# Altium schematic sheet power.SchDoc(power)
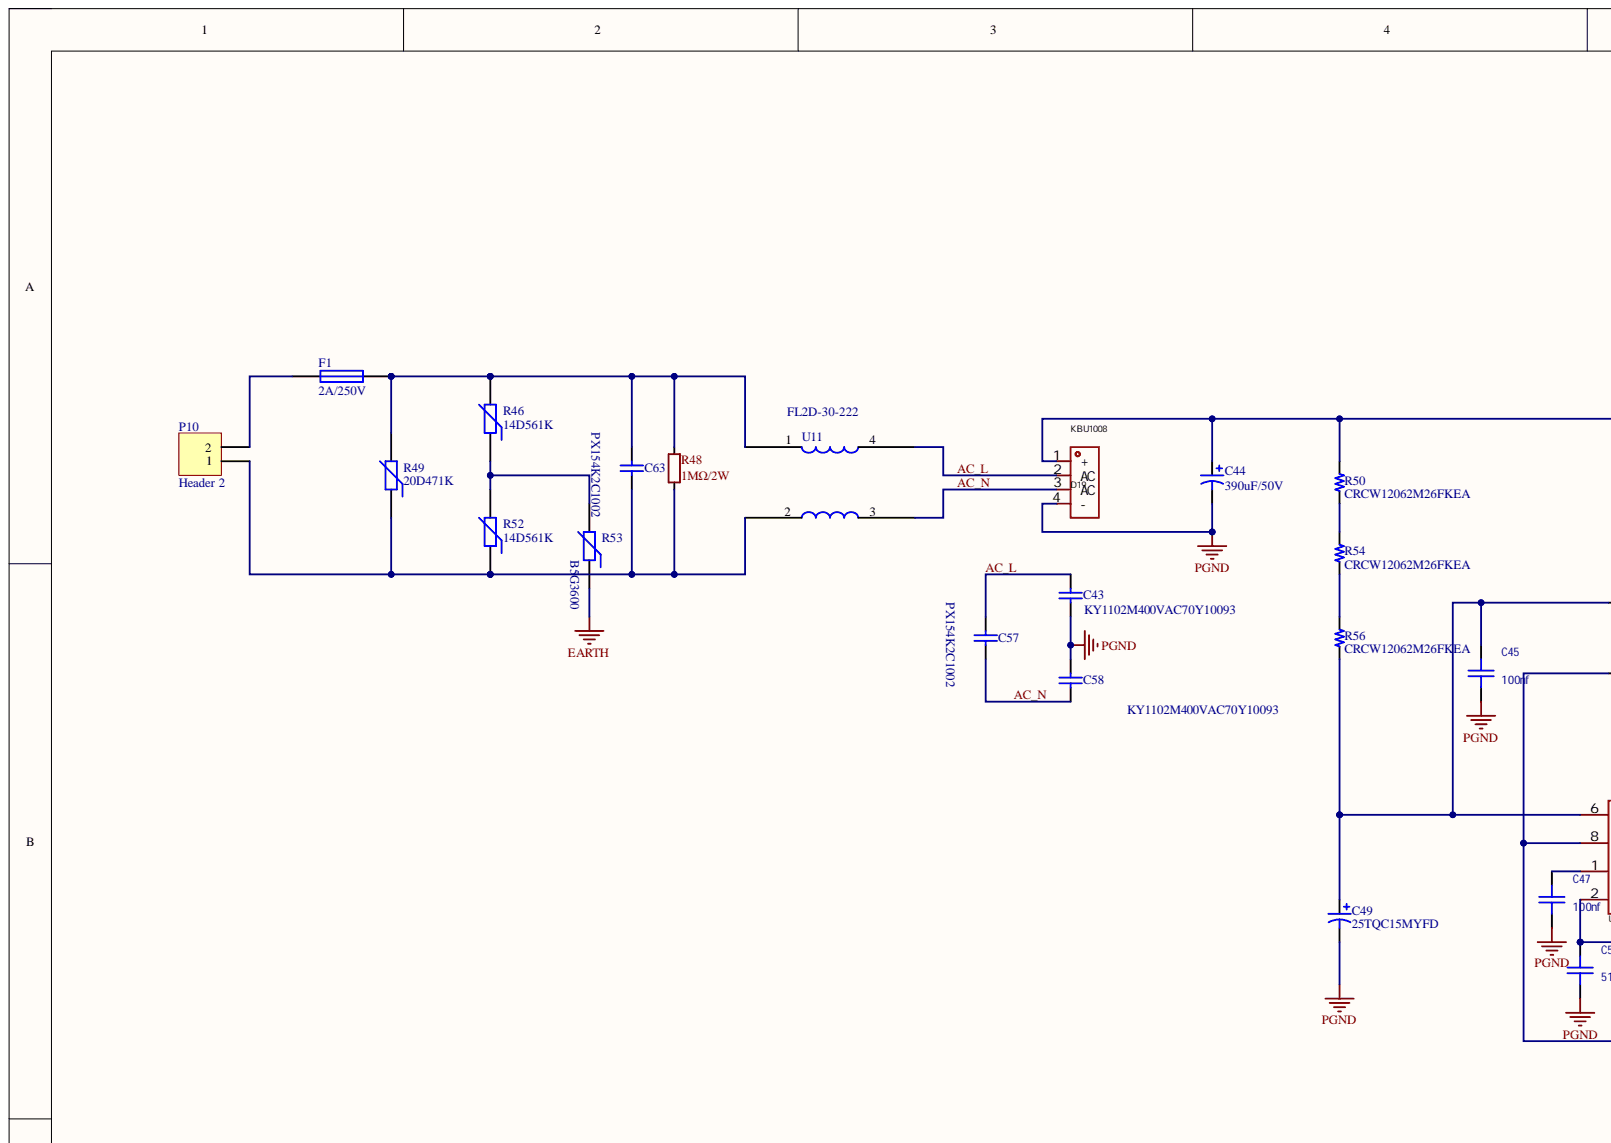
[diagram p0: power.SchDoc, top left]
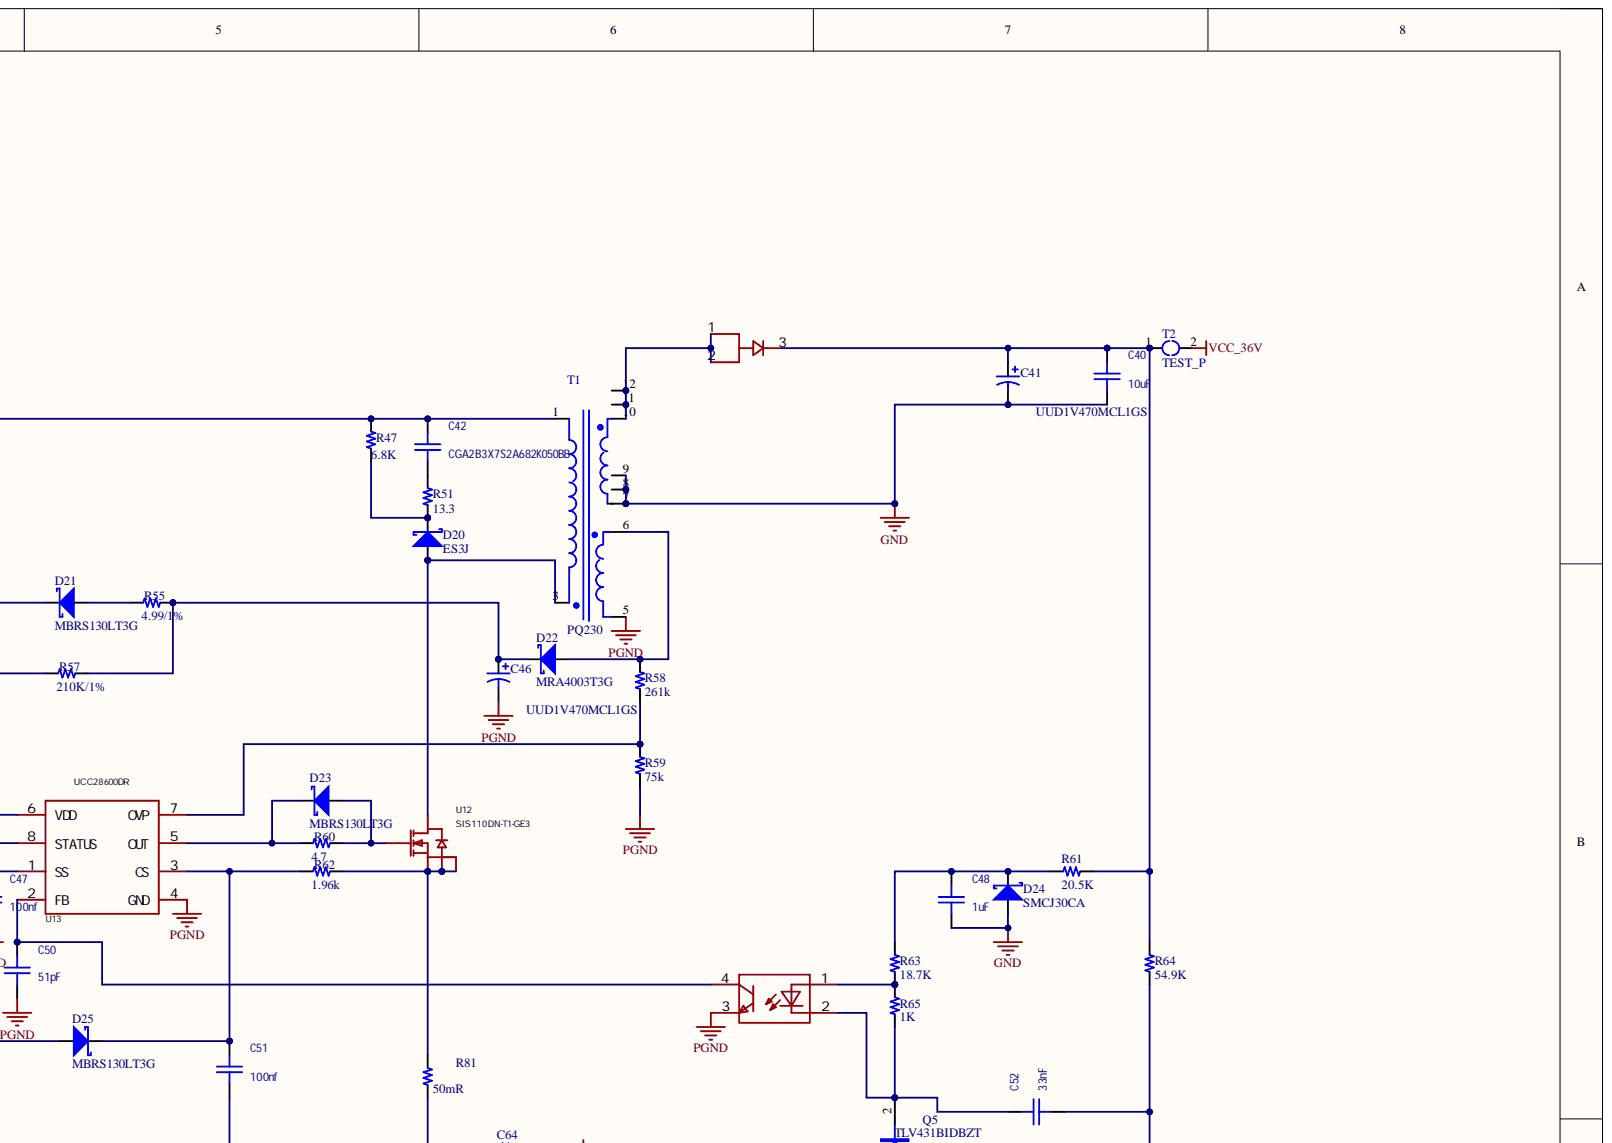
[diagram p1: power.SchDoc, top right]
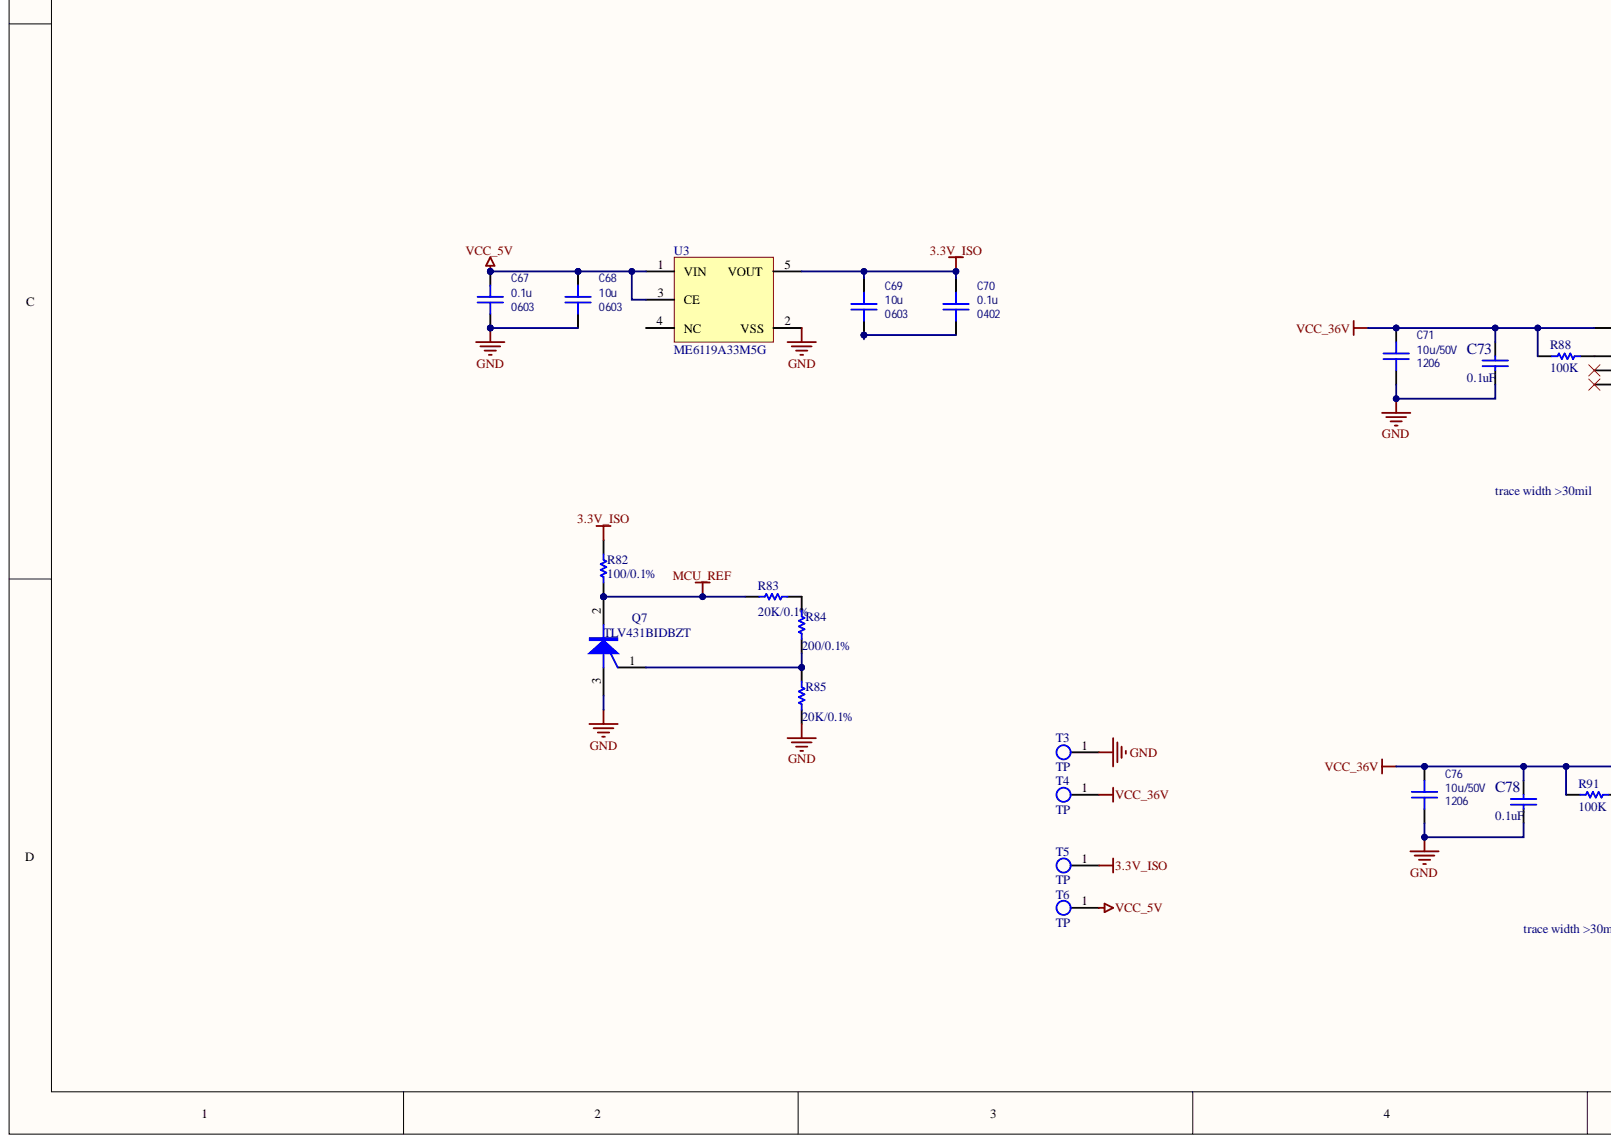
[diagram p2: power.SchDoc, bottom left]
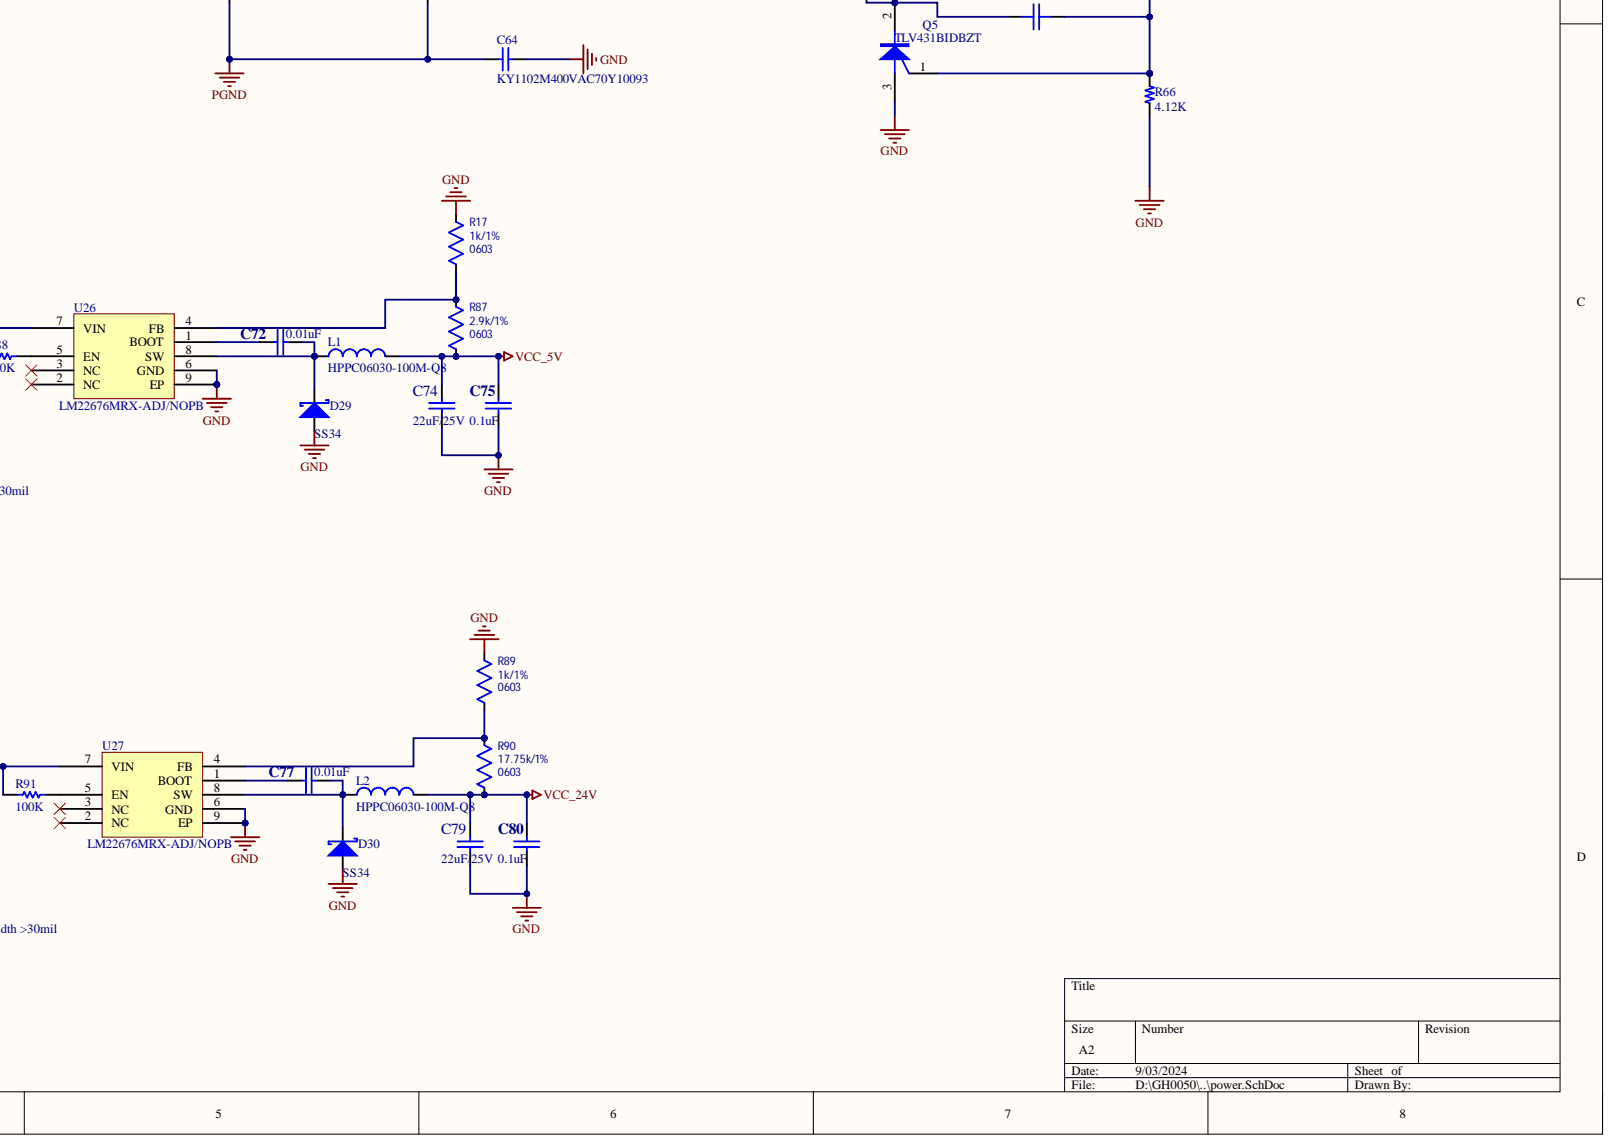
[diagram p3: power.SchDoc, bottom right]

## Parts
C40  Package: 10uF  [0603c]  (hidden comment: Capacitor_0603)
C41  UUD1V470MCL1GS  [Φ10×10]
C42  Package: CGA2B3X7S2A682K050BB  [0402c]  (hidden comment: Capacitor_0603)
C43  KY1102M400VAC70Y10093  [CAP-TH_L7.5-W4.5-P10.00-D0.6]
C44  390uF/50V  [LGX2H391MELC50]
C45  Package: 100nf  [0603c]  (hidden comment: Capacitor_0603)
C46  UUD1V470MCL1GS  [Φ10×10]
C47  Package: 100nf  [0603c]  (hidden comment: Capacitor_0603)
C48  Capacitor_0603  [0402c]  (value not drawn)
C49  25TQC15MYFD  [7343]
C50  Package: 51pF  [0603c]  (hidden comment: Capacitor_0603)
C51  Package: 100nf  [0603c]  (hidden comment: Capacitor_0603)
C52  Package: 33nF  [0603c]  (hidden comment: Capacitor_0603)
C57  PX154K2C1002  [CAP-TH_L13.0-W6.0-P10.00-D0.6]
C58  KY1102M400VAC70Y10093  [CAP-TH_L7.5-W4.5-P10.00-D0.6]
C63  PX154K2C1002  [CAP-TH_L13.0-W6.0-P10.00-D0.6]
C64  KY1102M400VAC70Y10093  [CAP-TH_L7.5-W4.5-P10.00-D0.6]
C67  value 0.1u  Package: 0603  [CAPC1608X092N_0603]  (hidden comment: Capacitor_0603)
C68  value 10u  Package: 0603  [CAPC1608X092N_0603]  (hidden comment: Capacitor_0603)
C69  value 10u  Package: 0603  [CAPC1608X092N_0603]  (hidden comment: Capacitor_0603)
C70  value 0.1u  Package: 0402  [CAPC1005X056N_0402]  (hidden comment: Capacitor_0402)
C71  value 10u/50V  Package: 1206  [1206C]  (hidden comment: Capacitor_1206)
C72  0.01uF  [0603C]
C73  0.1uF  [0603C]
C74  22uF/25V  [1206C]
C75  0.1uF  [0603C]
C76  value 10u/50V  Package: 1206  [1206C]  (hidden comment: Capacitor_1206)
C77  0.01uF  [0603C]
C78  0.1uF  [0603C]
C79  22uF/25V  [1206C]
C80  0.1uF  [0603C]
D18  SVT12100VB_R2_00001  [TO-277B_3P-L6.1-W4.3-LS7.0-BR]  (value not drawn)
D19  KBU1008  [KBU_4P-L23.2-W6.8-P5.10]
D20  ES3J  [SMC]
D21  MBRS130LT3G  [SMB]
D22  MRA4003T3G  [SMA]
D23  MBRS130LT3G  [SMB]
D24  SMCJ30CA  [SMC]
D25  MBRS130LT3G  [SMB]
D29  SS34  [SMA]
D30  SS34  [SMA]
F1  2A/250V  [可视开关电源保险丝座]
L1  HPPC06030-100M-Q8  [IND-SMD-L7.3-W6]
L2  HPPC06030-100M-Q8  [IND-SMD-L7.3-W6]
P10  Header 2  [HDR1X2]
Q5  TLV431BIDBZT  [SOT23-3]
Q7  TLV431BIDBZT  [SOT23-3]
R17  value 1k/1%  Package: 0603  [0603R]  (hidden comment: Resistor_0603)
R46  14D561K  [14D561K]
R47  6.8K  [0603R]
R48  1MΩ/2W  [5x15.5]
R49  20D471K  [20D471K]
R50  CRCW12062M26FKEA  [1206R]
R51  13.3  [0603R]
R52  14D561K  [14D561K]
R53  B5G3600  [B5G3600]
R54  CRCW12062M26FKEA  [1206R]
R55  4.99/1%  [0603R]
R56  CRCW12062M26FKEA  [1206R]
R57  210K/1%  [0603R]
R58  261k  [0603R]
R59  75k  [0603R]
R60  4.7  [0603R]
R61  20.5K  [0603R]
R62  1.96k  [0603R]
R63  18.7K  [0603R]
R64  54.9K  [0603R]
R65  1K  [0603R]
R66  4.12K  [0603R]
R81  50mR  [2512R]
R82  100/0.1%  [0603R]
R83  value 20K/0.1%  [0603R]  (hidden comment: 1K/0.1%)
R84  value 200/0.1%  [0603R]  (hidden comment: 1K/0.1%)
R85  value 20K/0.1%  [0603R]  (hidden comment: 1K/0.1%)
R87  value 2.9k/1%  Package: 0603  [0603R]  (hidden comment: Resistor_0603)
R88  100K  [0603R]
R89  value 1k/1%  Package: 0603  [0603R]  (hidden comment: Resistor_0603)
R90  value 17.75k/1%  Package: 0603  [0603R]  (hidden comment: Resistor_0603)
R91  100K  [0603R]
T1  PQ230  [pq3230]
T2  TEST_P  [TEST_P]
T3  TP  [TPD]
T4  TP  [TPD]
T5  TP  [TPD]
T6  TP  [TPD]
U3  ME6119A33M5G  [MCRN-SOT23-5_V]
U11  FL2D-30-222  [TY-100P]
U12  SIS110DN-T1-GE3  [DFN-8_L3.3-W3.3-P0.65-BL-2]
U13  UCC28600DR  [so-8]
U14  TCMT1107  [sop4]  (value not drawn)
U26  LM22676MRX-ADJ/NOPB  [SOP127P600X168_HS-8N]
U27  LM22676MRX-ADJ/NOPB  [SOP127P600X168_HS-8N]

## Nets
3.3V_ISO: C69.1 C70.1 R82.1 T5.1 U3.5(VOUT)
EARTH: R53.1
GND: C40.2 C41.2 C48.2 C64.1 C67.2 C68.2 C71.2 C73.1 C74.1 C75.2 C76.2 C78.1 C79.1 C80.2 D24.1 D29.1 D30.1 Q5.3 Q7.3 R17.2 R66.2 R85.2 R89.2 T1.7 T1.8 T1.9 T3.1 U3.2(VSS) U26.6(GND) U26.9(EP) U27.6(GND) U27.9(EP)
MCU_REF: Q7.2 R82.2 R83.1
PGND: C43.1 C44.2 C45.2 C46.2 C47.2 C49.2 C50.2 C51.2 C58.2 C64.2 D19.4(-) R59.2 R81.2 T1.5 U13.4(GND) U14.3
VCC_5V: C67.1 C68.1 C74.2 C75.1 L1.2 R87.1 T6.1 U3.1(VIN) U3.3(CE)
VCC_24V: C79.2 C80.1 L2.2 R90.1
VCC_36V: C71.1 C73.2 C76.1 C78.2 R88.2 R91.2 T2.2 T4.1 U26.7(VIN) U27.7(VIN)
AC_L: C43.2 C57.2 D19.2(AC) U11.4
AC_N: C57.1 C58.1 D19.3(AC) U11.3
NetC40_1: C40.1 C41.1 D18.3 R61.1 R64.1 T2.1
NetC42_1: C42.1 C44.1 D19.1(+) R47.2 R50.2 T1.1
NetC42_2: C42.2 R51.2
NetC45_1: C45.1 C49.1 D21.2 R56.1 U13.6(VDD)
NetC46_1: C46.1 D22.2 R55.1 R57.1
NetC47_1: C47.1 U13.1(SS)
NetC48_1: C48.1 D24.2 R61.2 R63.1
NetC50_1: C50.1 U13.2(FB) U14.4
NetC51_1: C51.1 D25.2 R62.2 U13.3(CS)
NetC52_1: C52.1 Q5.2 R65.2 U14.2
NetC52_2: C52.2 Q5.1 R64.2 R66.1
NetC63_1: C63.1 P10.1 R48.2 R49.1 R52.1 U11.2
NetC63_2: C63.2 F1.2 R46.2 R48.1 R49.2 U11.1
NetC69_2: C69.2 C70.2
NetC72_1: C72.1 D29.2 L1.1 U26.8(SW)
NetC72_2: C72.2 U26.1(BOOT)
NetC77_1: C77.1 D30.2 L2.1 U27.8(SW)
NetC77_2: C77.2 U27.1(BOOT)
NetD18_1: D18.1 D18.2 T1.10 T1.11 T1.12
NetD20_1: D20.1 T1.3 U12.5
NetD20_2: D20.2 R47.1 R51.1
NetD21_1: D21.1 R55.2
NetD22_1: D22.1 R58.1 T1.6
NetD23_1: D23.1 R60.1 U12.4
NetD23_2: D23.2 R60.2 U13.5(OUT)
NetD25_1: D25.1 R57.2 U13.8(STATUS)
NetF1_1: F1.1 P10.2
NetQ7_1: Q7.1 R84.2 R85.1
NetR17_1: R17.1 R87.2 U26.4(FB)
NetR46_1: R46.1 R52.2 R53.2
NetR50_1: R50.1 R54.2
NetR54_1: R54.1 R56.2
NetR58_2: R58.2 R59.1 U13.7(OVP)
NetR62_1: R62.1 R81.1 U12.1 U12.2 U12.3
NetR63_2: R63.2 R65.1 U14.1
NetR83_2: R83.2 R84.1
NetR88_1: R88.1 U26.5(EN)
NetR89_1: R89.1 R90.2 U27.4(FB)
NetR91_1: R91.1 U27.5(EN)

Not connected: U3 4(NC); U26 2(NC) 3(NC); U27 2(NC) 3(NC)

## Library data of the parts
C40 C42 C45 C47 C48 C50-C52 C68 C69 = Capacitor_0603: Capacitor, MLCC; Manufacturer: Murata Electronics; PartNumber: GRM188R61A106KE69D; TempCo: [tempco]; Tolerance: [tol]; Voltage Rating: [voltage]; Component Kind: Standard; ComponentLink1Description: Datasheet; SupplierDescription: CAP CER 10UF 10V X5R 0603; Library Name: Karl.SchLib
  C48: Package: 1uF
C41 C44 C46 C49 = Cap Pol1: Polarized Capacitor (Radial); Value: 220uF/50V; Component Kind: Standard; LatestRevisionDate: 17-Jul-2002; LatestRevisionNote: Re-released for DXP Platform.; Library Name: Miscellaneous Devices.IntLib; PackageReference: RB7.6-15; Published: 8-Jun-2000; Signal Integrity: Cap Pol; Simulation: CAP; Publisher: Altium Limited
C43 C57 C58 C63 C64 = Cap: Capacitor; Value: 22nF; Component Kind: Standard; LatestRevisionDate: 17-Jul-2002; LatestRevisionNote: Re-released for DXP Platform.; Library Name: Miscellaneous Devices.IntLib; PackageDescription: Radial Cap, Thru-Hole; 2 Leads; 0.3 in Pin Spacing; PackageReference: RAD-0.3; Published: 8-Jun-2000; Signal Integrity: Cap; Simulation: CAP; Publisher: Altium Limited
C67 = Capacitor_0603: Capacitor, MLCC; Manufacturer: KEMET; PartNumber: C0603C104M5RACTU; TempCo: [tempco]; Tolerance: [tol]; Voltage Rating: [voltage]; Component Kind: Standard; ComponentLink1Description: Datasheet; SupplierDescription: CAP CER 0.1UF 50V X7R 0603; Library Name: Karl.SchLib
C70 = Capacitor_0402: Capacitor, MLCC; Manufacturer: Murata Electronics; PartNumber: GRM155R71C104KA88J; TempCo: [tempco]; Tolerance: [tol]; Voltage Rating: [voltage]; Component Kind: Standard; ComponentLink1Description: Datasheet; SupplierDescription: CAP CER 0.1UF 16V X7R 0402; Library Name: Karl.SchLib
C71 C76 = Capacitor_1206: PartNumber: C1206C106M3PACTU; TempCo: [tempco]; Tolerance: [tol]; Voltage Rating: [voltage]; Component Kind: Standard; SupplierDescription: CAP CER 10UF 25V X5R 1206; Library Name: Karl.SchLib
C72 C77 = CAP: Value: 0.01u/50V; Component Kind: Standard
C73 C75 C78 C80 = CAP: Value: 0.1uF/50V; Component Kind: Standard
C74 C79 = CAP: Component Kind: Standard; Value: 22uF/25V
D18 = SVT12100VB_R2_00001: Manufacturer: PANJIT(强茂); Add into BOM: yes; Average Rectified Current (Io): 12A; Forward Voltage (Vf@If): 670mV@12A; Reverse Leakage Current (Ir): 100uA@100V; Reverse Voltage (Vr): 100V; Component Kind: Standard; Convert to PCB: yes; Datasheet: https://atta.szlcsc.com/upload/public/pdf/source/20191009/C391735_74715AF6864FC3D0E0C927F6D6BDC70E.pdf; Device: SVT12100VB_R2_00001; JLCPCB Part Class: Extended Part; LCSC Part Name: 电压:100V 电流:12A; Manufacturer Part: SVT12100VB_R2_00001; Supplier: LCSC; Supplier Footprint: TO-277B; Supplier Part: C391735; Symbol: SVT12100VB_R2_00001
D19 = KBU1008: Manufacturer: YANGJIE(扬杰); Add into BOM: yes; Average Rectified Current (Io): 10A; Forward Voltage (Vf@If): 1.1V@5A; Peak Forward Surge Current: 170A; Reverse Leakage Current (Ir): 10uA@800V; Reverse Voltage (Vr): 800V; Symbol: KBU1008-A2-0000; Component Kind: Standard; Convert to PCB: yes; Datasheet: https://atta.szlcsc.com/upload/public/pdf/source/20200429/C525723_C60B0D61B4DEC997859D4E414530B852.pdf; Device: KBU1008; JLCPCB Part Class: Extended Part; LCSC Part Name: 电压:800V 电流:10A; Manufacturer Part: KBU1008; Operating Temperature: -55℃~+150℃@(Tj); Supplier: LCSC; Supplier Footprint: KBU; Supplier Part: C781391
D20-D25 = DIODE S: Schottky Diode; Component Kind: Standard
D29 D30 = DIODE S: Component Kind: Standard; Value: SS34
F1 = Fuse 1: Fuse; Component Kind: Standard; LatestRevisionDate: 17-Jul-2002; LatestRevisionNote: Re-released for DXP Platform.; Library Name: Miscellaneous Devices.IntLib; PackageDescription: Thru-Hole; 2 Leads; PackageReference: PIN-W2/E2.8; Published: 8-Jun-2000; Simulation: FUSE; Publisher: Altium Limited
L1 L2 = 1276AS-H-100M=P2: Rated Current: 250 mA; Component Kind: Standard; Mounting Technology: Surface Mount; PackageDescription: 2-Pin SMT Inductor, 3.2 x 2.5 mm Body; PackageReference: IND1210; PartNumber: 1276AS-H-100M=P2; Value: HPPC06030-100M-Q8; Library Name: Bogen.SchLib
P10 = Header 2: Header, 2-Pin; Component Kind: Standard; LatestRevisionDate: 17-Jul-2002; LatestRevisionNote: Re-released for DXP Platform.; Library Name: Miscellaneous Connectors.IntLib; Signal Integrity: Connector; Publisher: Altium Limited
Q5 Q7 = PUT: Programmable Unijunction Transistor; Component Kind: Standard; LatestRevisionDate: 17-Jul-2002; LatestRevisionNote: Re-released for DXP Platform.; Library Name: Miscellaneous Devices.IntLib; PackageReference: TO-52; Published: 8-Jun-2000; Simulation: PUT; Publisher: Altium Limited
R17 R87 R89 R90 = Resistor_0603: PartNumber: RC0603FR-1075KL; Power Rating: [power]; TempCo: [tempco]; Tolerance: tol; Component Kind: Standard; SupplierDescription: RES SMD 75K OHM 1% 1/10W 0603; Library Name: Karl.SchLib
R46 R49 R52 R53 = Res Varistor: Varistor (Voltage-Sensitive Resistor); Code_IPC: RESC2012; Component Kind: Standard; LatestRevisionDate: 29-May-2009; LatestRevisionNote: IPC-7351 Footprint Added.; Library Name: Miscellaneous Devices.IntLib; PackageDescription: Chip Resistor 0805 Case Size; PackageReference: 6-0805; PackageVersion: Mar-2003; Published: 24-Mar-1999; Publisher: Altium Limited
R47 R50 R51 R54-R66 R81 = R: Component Kind: Standard; Description: R; Library Name: JRJ_1.SCHLIB
R48 = RES0603: ID: 233; Value: 100K; 制造商: 三星/YAGEO; 参数值: 1.00E+04; 器件等级: ——; 工作温度: –55°C to 155°C; 序号: 109; 物料主编码(PN): 150000040; 物料名称: 贴片电阻; 物料描述: RES,贴片电阻,0603,10k,±1%,1/10W,1.6X0.8X0.45mm,RC1608F103CS/RC0603FR-0710KL; 物料类型: 表贴电阻; 精度: ±1%; 规格型号(完整型号): RC1608F103CS/RC0603FR-0710KL; Component Kind: Standard; Library Name: RC_M_D_00.IntLib
R82-R85 = Res1: Resistor; Component Kind: Standard; LatestRevisionDate: 17-Jul-2002; LatestRevisionNote: Re-released for DXP Platform.; Library Name: Miscellaneous Devices.IntLib; PackageReference: AXIAL-0.3; Published: 8-Jun-2000; Signal Integrity: Res; Simulation: RESISTOR; Publisher: Altium Limited
R88 R91 = Res1: Component Kind: Standard; Library Name: Miscellaneous Devices.IntLib; PackageReference: AXIAL-0.3; Published: 8-Jun-2000; Signal Integrity: Res; Simulation: RESISTOR; Value: 100K; Publisher: Altium Limited
U3 = ME6119C50M5G: High Speed LDO Regulators, Low ESR, 5.0 V, 400 mA, -40 to 125 degC, 5-Pin SOT-23, RoHS, Tape and Reel; Dropout Voltage: 160mV; Max Input Voltage: 5.5V; Min Current Limit: 400mA; Min Input Voltage: 2.5V; Nominal Output Voltage: 3.3V; Number of Regulators: 1; Output Current: 300mA; Case\/Package: SOT-23; Component Kind: Standard; Datasheet URL: http://ww1.microchip.com/downloads/en/DeviceDoc/MIC550X.pdf; Datasheet Version: Rev. 2.3, 04/2015; Lead Free: LeadFree; Manufacturer URL: http://www.microchip.com/; Max Operating Temperature: 125degC; Min Operating Temperature: -40degC; Mount: SurfaceMount; Mounting Technology: SurfaceMount; Number of Pins: 5; Output Voltage: 3.3V; Package Description: 5-Pin Small Outline Transistor, Body 1.6 x 2.9 mm, Pitch 0.95 mm; Package Reference: SOT-23; Packaging: CutTape; REACH SVHC: NoSVHC; RoHSCompliant: True; Library Name: Bogen.SchLib
U11 = TY-100P: PCB mounting filters; Component Kind: Standard; Library Name: power.SCHLIB
U12 = SIS110DN-T1-GE3: Manufacturer: VISHAY(威世); Add into BOM: yes; Component Kind: Standard; Convert to PCB: yes; Datasheet: https://atta.szlcsc.com/upload/public/pdf/source/20221230/028E61738915F263ABF2615161A8D7B6.pdf; Device: SIS110DN-T1-GE3; JLCPCB Part Class: Extended Part; LCSC Part Name: SIS110DN-T1-GE3; Manufacturer Part: SIS110DN-T1-GE3; Supplier: LCSC; Supplier Footprint: SO-8; Supplier Part: C5334354; Symbol: SIS110DN-T1-GE3
U13 = UCC28600DR: Manufacturer: TI(德州仪器); Add into BOM: yes; Duty Cycle: 99%; Output Isolation: 隔离; Supply Voltage: 8V~21V; Switching Frequency: 40kHz~130kHz; Topology: 反激式; Component Kind: Standard; Convert to PCB: yes; Datasheet: https://www.ti.com/cn/lit/gpn/ucc28600; Device: UCC28600DR; JLCPCB Part Class: Extended Part; LCSC Part Name: UCC28600DR; Library Name: UCC28600DR1_UCC28600DR.SCHLIB; Manufacturer Part: UCC28600DR; Operating Temperature: -40℃~+105℃@(Ta); Supplier: LCSC; Supplier Footprint: SOIC-8; Supplier Part: C28585; Symbol: UCC28600DR
U14 = TCMT1107: Manufacturer: VISHAY(威世); Add into BOM: yes; Collector-Emitter Saturation Voltage (Vce(sat)@Ic,IF): 300mV@1mA,10mA; Fall time: 7us@2mA,100Ω; Forward Voltage: 1.35V; Input voltage type: DC; Isolation Voltage(rms): 3.75kV; Number of Outputs: 1; Output Current: 50mA; Output Type: 光电三极管; Receiving End Voltage: 70V; Reverse Voltage: 6V; Rise time: 5.5us@2mA,100Ω; Component Kind: Standard; Convert to PCB: yes; Datasheet: https://atta.szlcsc.com/upload/public/pdf/source/20170713/C118299_1499918878383816727.pdf; Device: TCMT1107; JLCPCB Part Class: Extended Part; LCSC Part Name: DC输入 隔离电压(rms):3750V; Manufacturer Part: TCMT1107; Operating Temperature: -40℃~+100℃; Supplier: LCSC; Supplier Footprint: SOP-4-1.27mm; Supplier Part: C81148; Symbol: TCMT1107
U26 U27 = LM22676MR-5.0: Value: LM22676MRE-5.0/NOPB; Component Kind: Standard; Library Name: Schlib1.SchLib
T1 = PQ230: Component Kind: Standard; Library Name: Schlib_20110825.schlib
T2 = TEST_P: Component Kind: Standard; Description: TEST_P; Library Name: Schlib_20110825.schlib
T3-T6 = TP: Component Kind: Standard; Library Name: Schlib_20110825.schlib
